# Altium schematic sheet Gateway_Power_Converter_Board.SchDoc
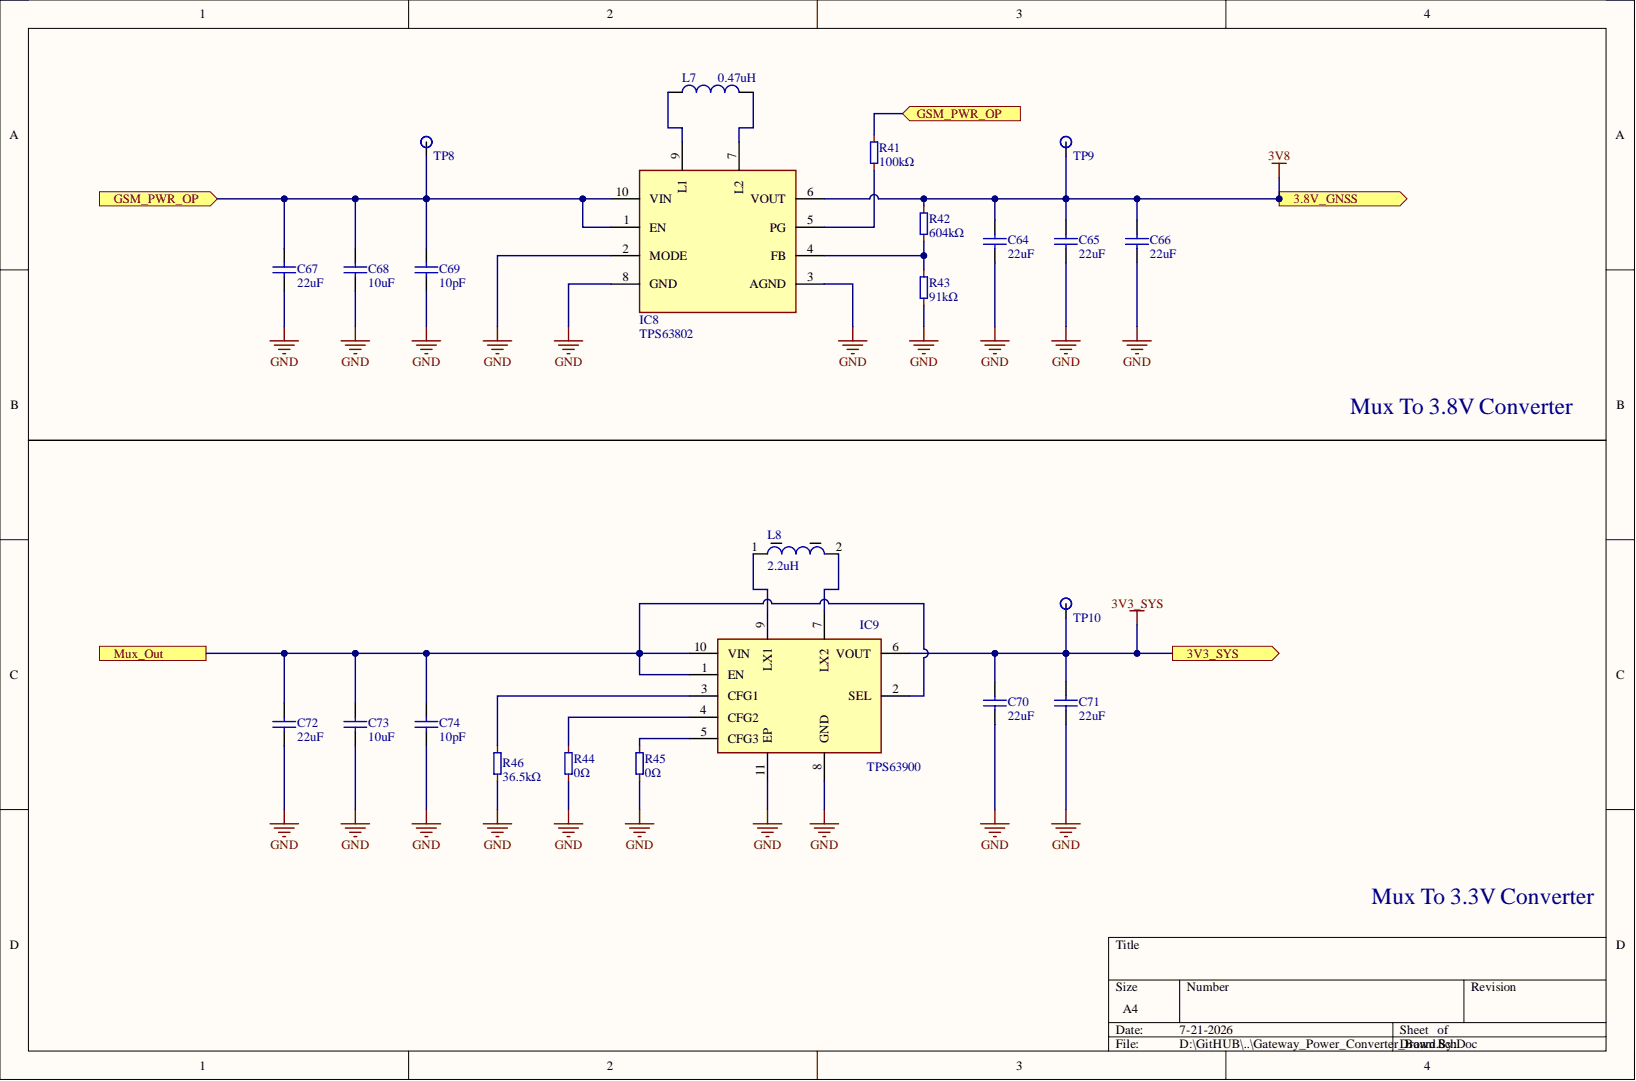
[diagram p0: Gateway_Power_Converter_Board.SchDoc]

## Parts
C64  22uF  [CAP0402]
C65  22uF  [CAP0402]
C66  22uF  [CAP0402]
C67  22uF  [CAP0402]
C68  10uF  [CAP0402]
C69  10pF  [CAP0402]
C70  22uF  [CAP0402]
C71  22uF  [CAP0402]
C72  22uF  [CAP0402]
C73  10uF  [CAP0402]
C74  10pF  [CAP0402]
IC8  TPS63802  [TPS63802]
IC9  TPS63900  [TPS63900]
L7  0.47uH  [Inductor 1616]
L8  2.2uH  [Ind 2.2uH]
R41  100kΩ  [RESC0402]
R42  604kΩ  [RESC0402]
R43  91kΩ  [RESC0402]
R44  0Ω  [RESC0402]
R45  0Ω  [RESC0402]
R46  36.5kΩ  [RESC0402]
TP8  Test Point  [Test Point]  (value not drawn)  (no BOM)
TP9  Test Point  [Test Point]  (value not drawn)  (no BOM)
TP10  Test Point  [Test Point]  (value not drawn)  (no BOM)

## Ports
3.8V_GNSS, 3V3_SYS, GSM_PWR_OP, Mux_Out

## Nets
GND: C64.1 C65.1 C66.1 C67.1 C68.2 C69.2 C70.1 C71.1 C72.1 C73.2 C74.2 IC8.2(MODE) IC8.3(AGND) IC8.8(GND) IC9.8(GND) IC9.11(EP) R43.1 R44.1 R45.1 R46.1
3V3_SYS: C70.2 C71.2 IC9.6(VOUT) TP10.1 ; port 3V3_SYS
3V8: C64.2 C65.2 C66.2 IC8.6(VOUT) R42.2 TP9.1 ; port 3.8V_GNSS
GSM_PWR_OP: C67.2 C68.1 C69.1 IC8.1(EN) IC8.10(VIN) R41.2 TP8.1 ; port GSM_PWR_OP
Mux_Out: C72.2 C73.1 C74.1 IC9.1(EN) IC9.2(SEL) IC9.10(VIN) ; port Mux_Out
NetIC8_4: IC8.4(FB) R42.1 R43.2
NetIC8_5: IC8.5(PG) R41.1
NetIC8_7: IC8.7(L2) L7.2
NetIC8_9: IC8.9(L1) L7.1
NetIC9_3: IC9.3(CFG1) R46.2
NetIC9_4: IC9.4(CFG2) R44.2
NetIC9_5: IC9.5(CFG3) R45.2
NetIC9_7: IC9.7(LX2) L8.2
NetIC9_9: IC9.9(LX1) L8.1

## Library data of the parts
C64-C67 C70-C72 = CAP_0402: Ceramic Capacitor - SMD 22uF X5R 0402 20% 6.3V; Manufacturer: Yageo; Manufacturer_Part_Number: GRM158R61A226ME15D; Component Kind: Standard; Supplier: Robu; Supplier_Part_Number: GRM158R61A226ME15D; Library Name: macnman_2.0.SchLib
C68 C73 = CAP_0402: Ceramic Capacitor - SMD 0402 10uF 20% 6.3V X5R; Manufacturer: MURATA; Manufacturer_Part_Number: GRM155R60J106ME15D; Component Kind: Standard; Supplier: Robu; Supplier_Part_Number: GRM155R60J106ME15D; Library Name: macnman_2.0.SchLib
C69 C74 = Capa_0402: Ceramic Capacitor C0G, NP0 0402 10pF 35V; Manufacturer: YAGEO; Manufacturer_Part_Number: CC0402JRNPO9BN100; Component Kind: Standard; Library Name: macnman_2.0.SchLib
IC8 = TPS63802: 2-A, High-efficient, Low IQ Buck-boost Converter; Manufacturer: Texas Instrument; Component Kind: Standard; Manufacturer_Part_Number: TPS63802; Supplier 1: DigiKey; Supplier Part Number 1: 296-TPS63802DLARDKR-ND; Library Name: macnman_3.0.SchLib
IC9 = TPS63900: 1.8-V to 5.5-V, 75-nA IQ Buck-boost Converter; Manufacturer: Texas Instrument; Component Kind: Standard; Manufacturer_Part_Number: TPS63900; Supplier 1: DigiKey; Supplier Part Number 1: 296-TPS63900DSKRDKR-ND; Library Name: macnman_3.0.SchLib
L7 = Inductor: Power Inductor SMD -  0.47 µH 5A Shielded 2A 1616; Manufacturer: Coilcraft; Manufacturer_Part_Number: XFL4015-471ME; Component Kind: Standard; Supplier 1: Mouser; Supplier Part Number 1: 994-XFL4015-471MEC; Library Name: macnman_3.0.SchLib
L8 = Inductor: FIXED Imductor - SMD 2.2UH 6.5A 20 MOHM 20%; Manufacturer: Wurth; Manufacturer_Part_Number: 74437346022; Component Kind: Standard; Library Name: Inductor_2.2uH.SchLib; Supplier 1: DigiKey; Supplier Part Number 1: 732-3372-1-ND
R41 = Resister: Thick Flim Resistor - SMD 100k 0805 0.5% 100ppm 1/8W; Manufacturer: Yageo; Manufacturer_Part_Number: RC0402DR-07100KL; Component Kind: Standard; Package: 0805; Supplier: Robu; Supplier_Part_Number: RC0402DR-07100KL; Library Name: macnman_3.0.SchLib
R42 = Resister: Thin Film Resistor - SMD 604k 0805 0.1% 1/8  25ppm; Manufacturer: Panasonic; Manufacturer_Part_Number: ERA-6AEB6043V; Component Kind: Standard; Package: 0805; Supplier 1: DigiKey; Supplier Part Number 1: ERA-6AEB6043V; Library Name: macnman_3.0.SchLib
R43 = Resister: Thin Film Resistor - SMD 91k 0805 1% 1/8W; Manufacturer: Yageo; Manufacturer_Part_Number: RC0805FR-0791KL; Packagef: 0805; Component Kind: Standard; Supplier 1: Robu; Supplier Part Number 1: RC0805FR-0791KL; Library Name: macnman_3.0.SchLib
R44 R45 = RES_0402: Thick Film Resistors - SMD 0Ohm 0402 1/16W; Manufacturer: YAGEO; Manufacturer_Part_Number: RC0402FR-070RL; Component Kind: Standard; ComponentLink1Description: New link; Supplier: Robu; Supplier_Part_Number: RC0402FR-070RL; Library Name: macnman_2.0.SchLib
R46 = RES_0402: Thick Film Resistor - SMD 36.5kΩ 0402 100ppm 50V 1/16W; Manufacturer: YAGEO; Manufacturer_Part_Number: AC0402FR-0736K5L; Component Kind: Standard; ComponentLink1Description: New link; Package: 0402; Supplier: Robu; Supplier_Part_Number: AC0402FR-0736K5L; Library Name: macnman_2.0.SchLib
TP8-TP10 = Test Point: Test Point 1mm; Library Name: macnman_2.0.SchLib
